# Altium schematic sheet PFM_GPRS.SchDoc(PFM_GPRS)
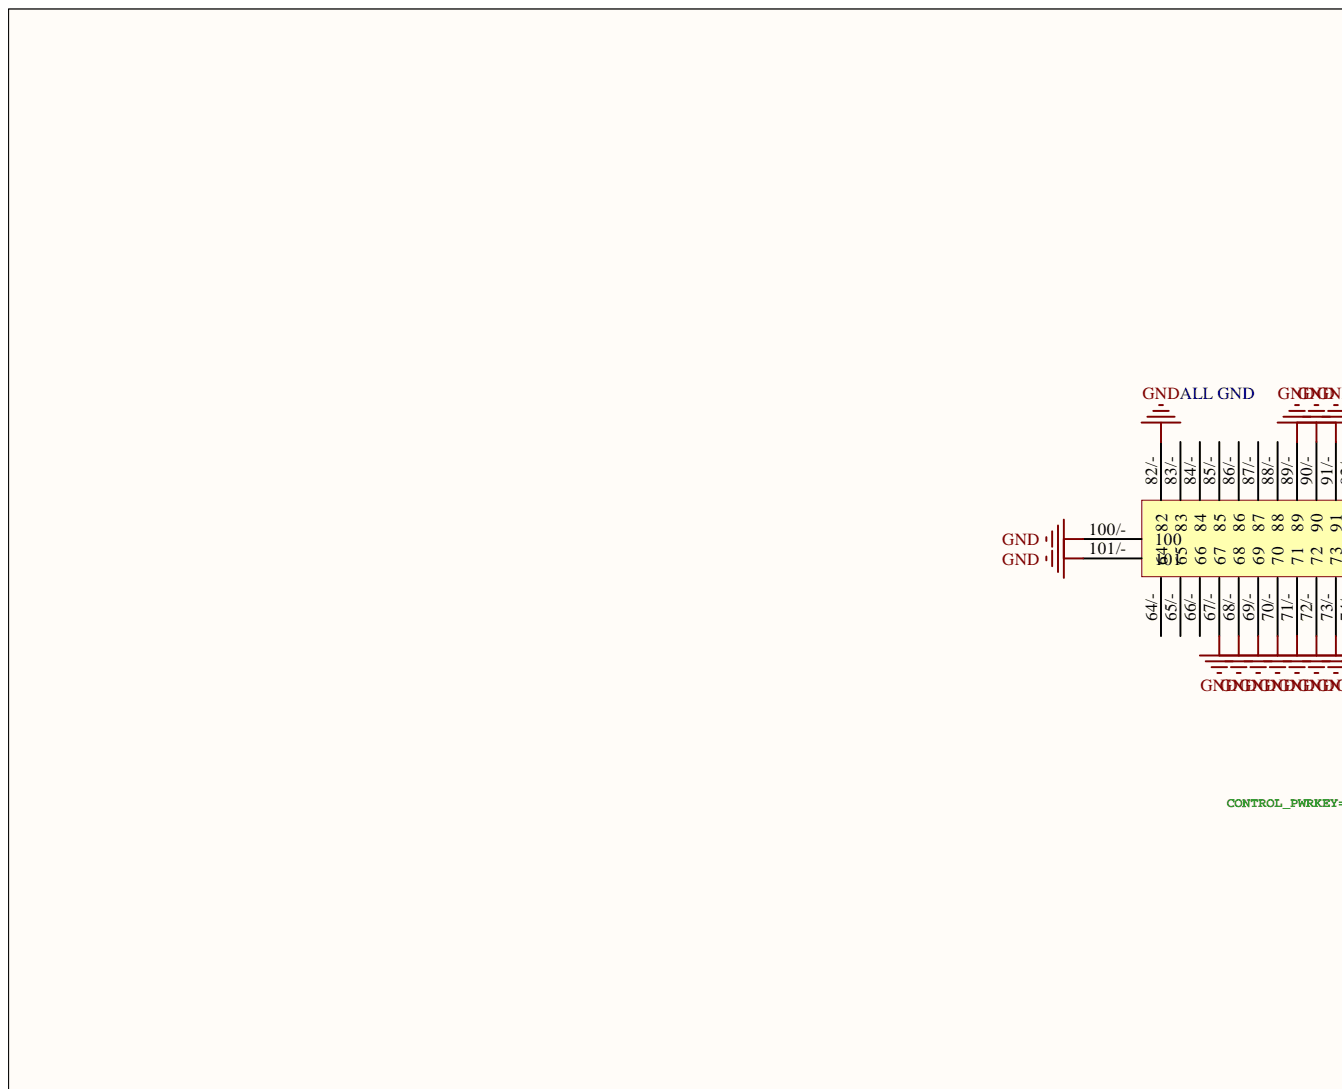
[diagram p0: PFM_GPRS.SchDoc, top left]
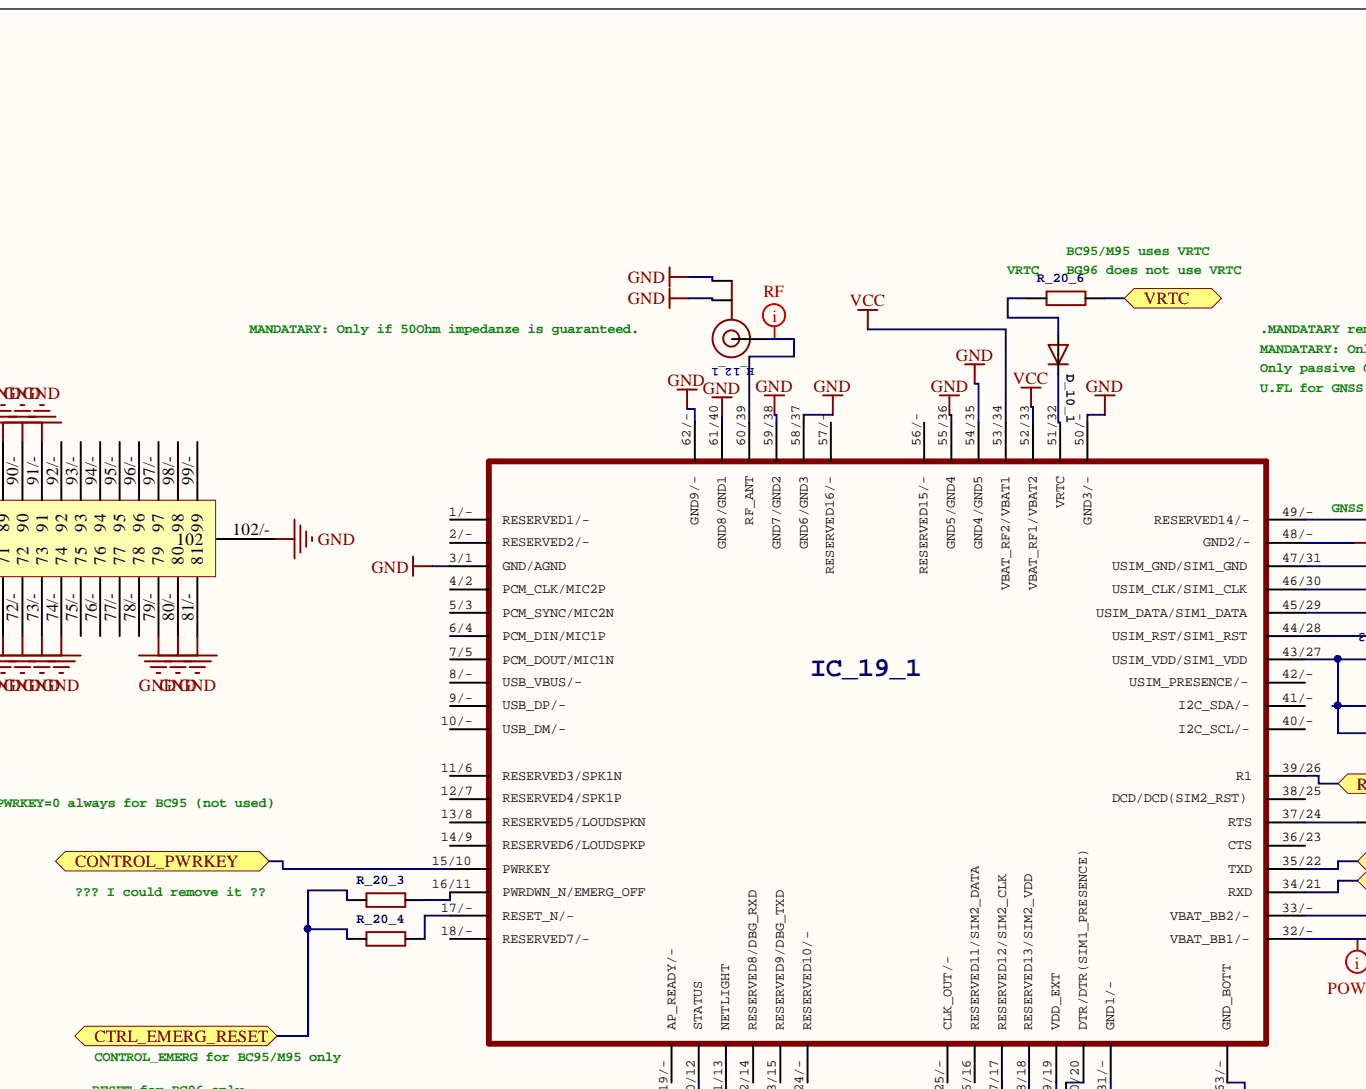
[diagram p1: PFM_GPRS.SchDoc, top centre]
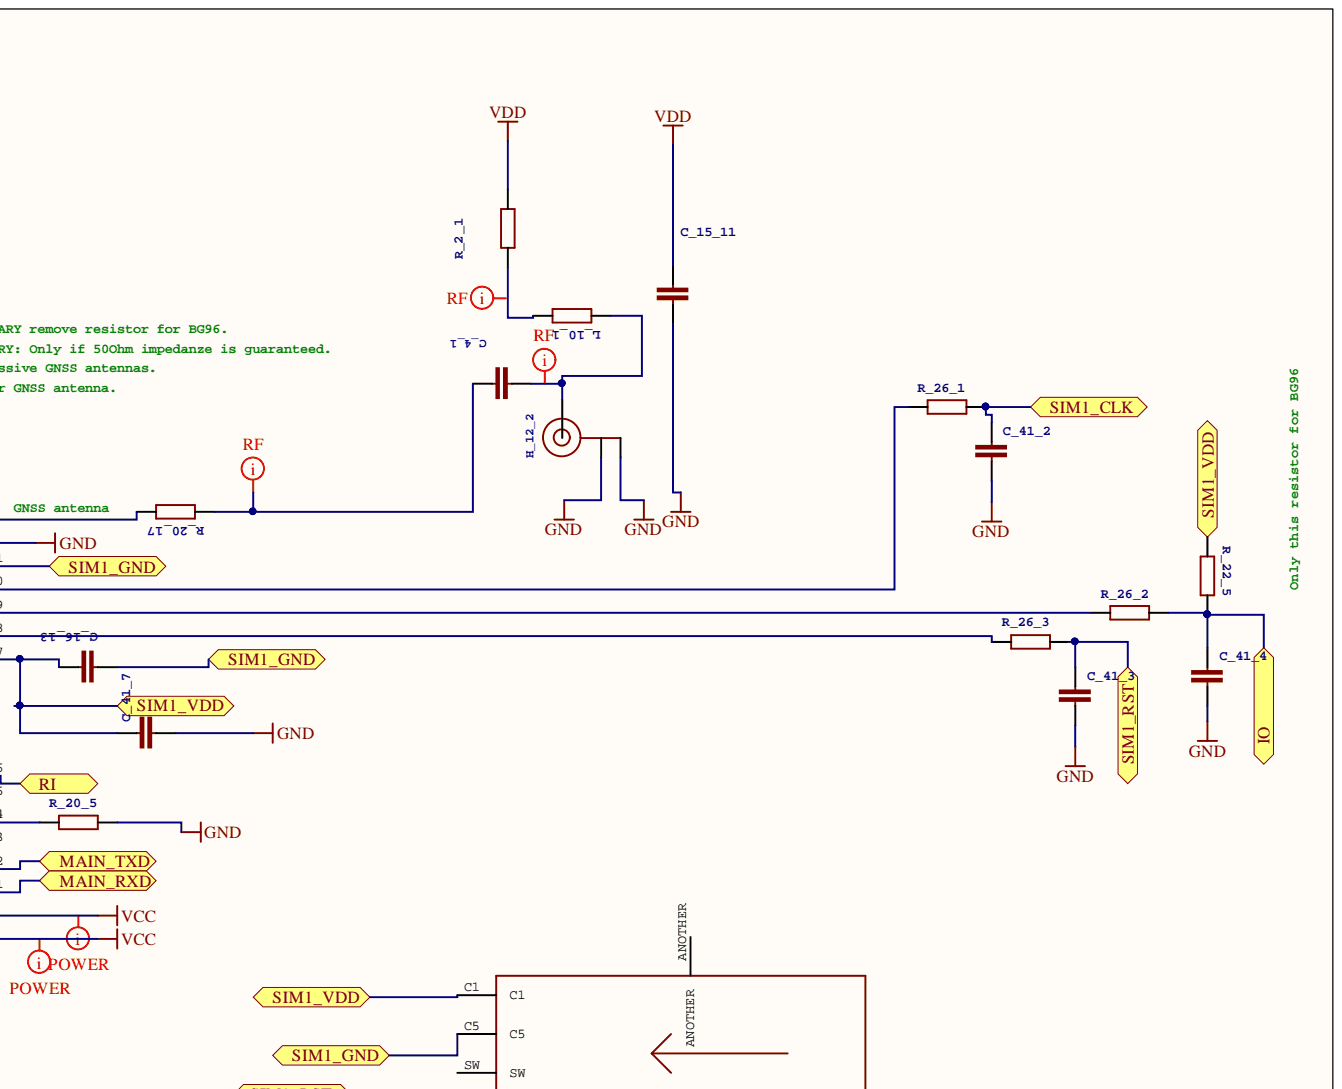
[diagram p2: PFM_GPRS.SchDoc, top right]
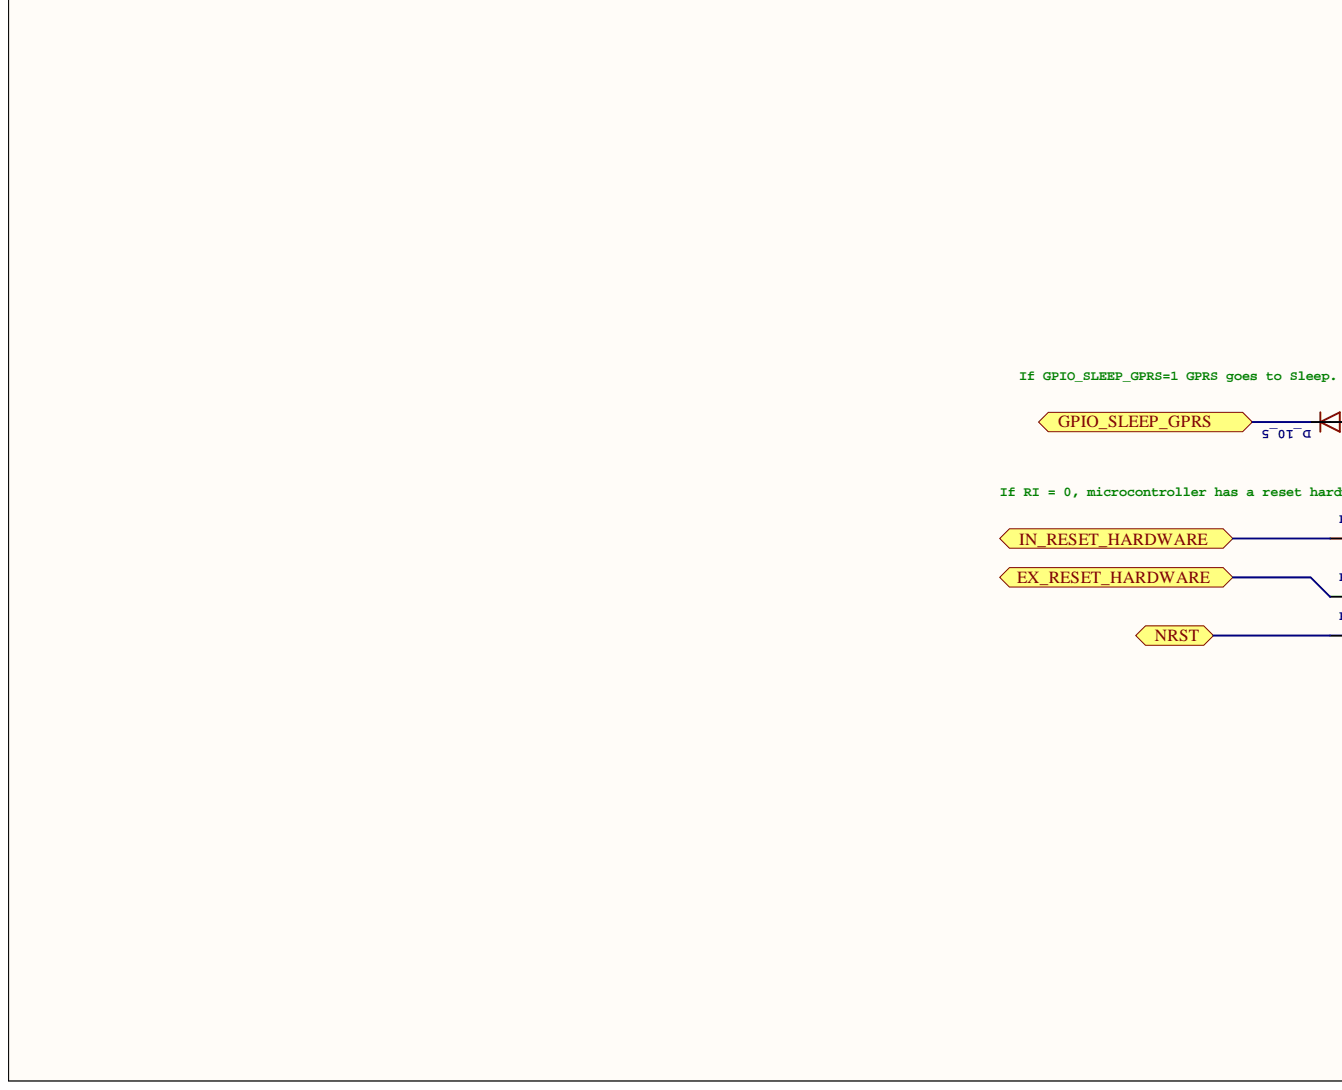
[diagram p3: PFM_GPRS.SchDoc, bottom left]
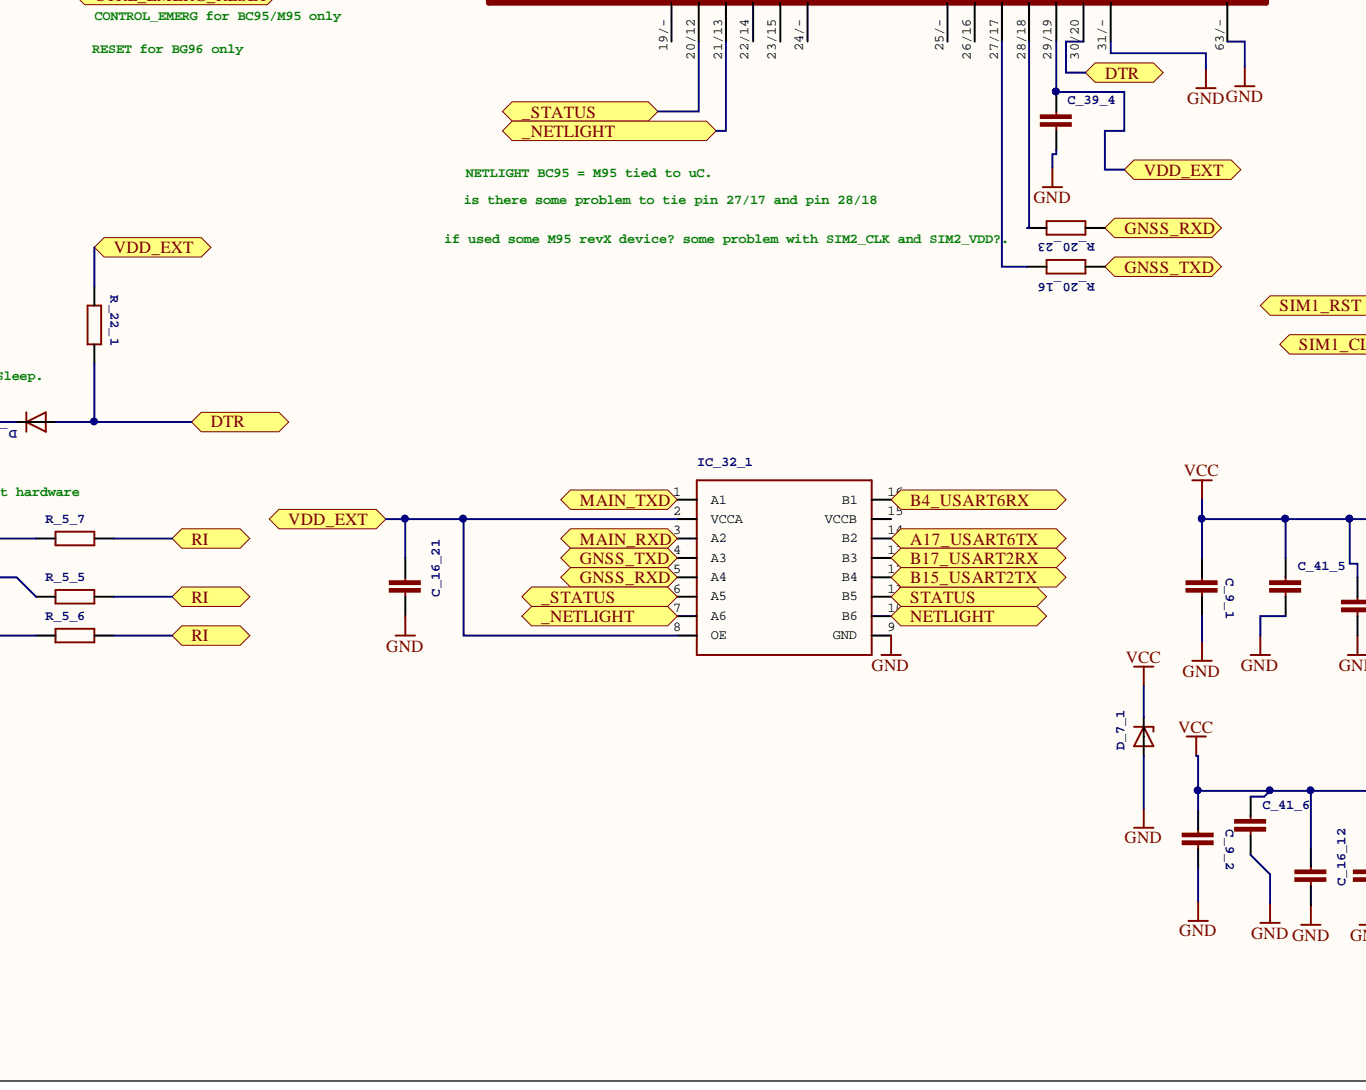
[diagram p4: PFM_GPRS.SchDoc, bottom centre]
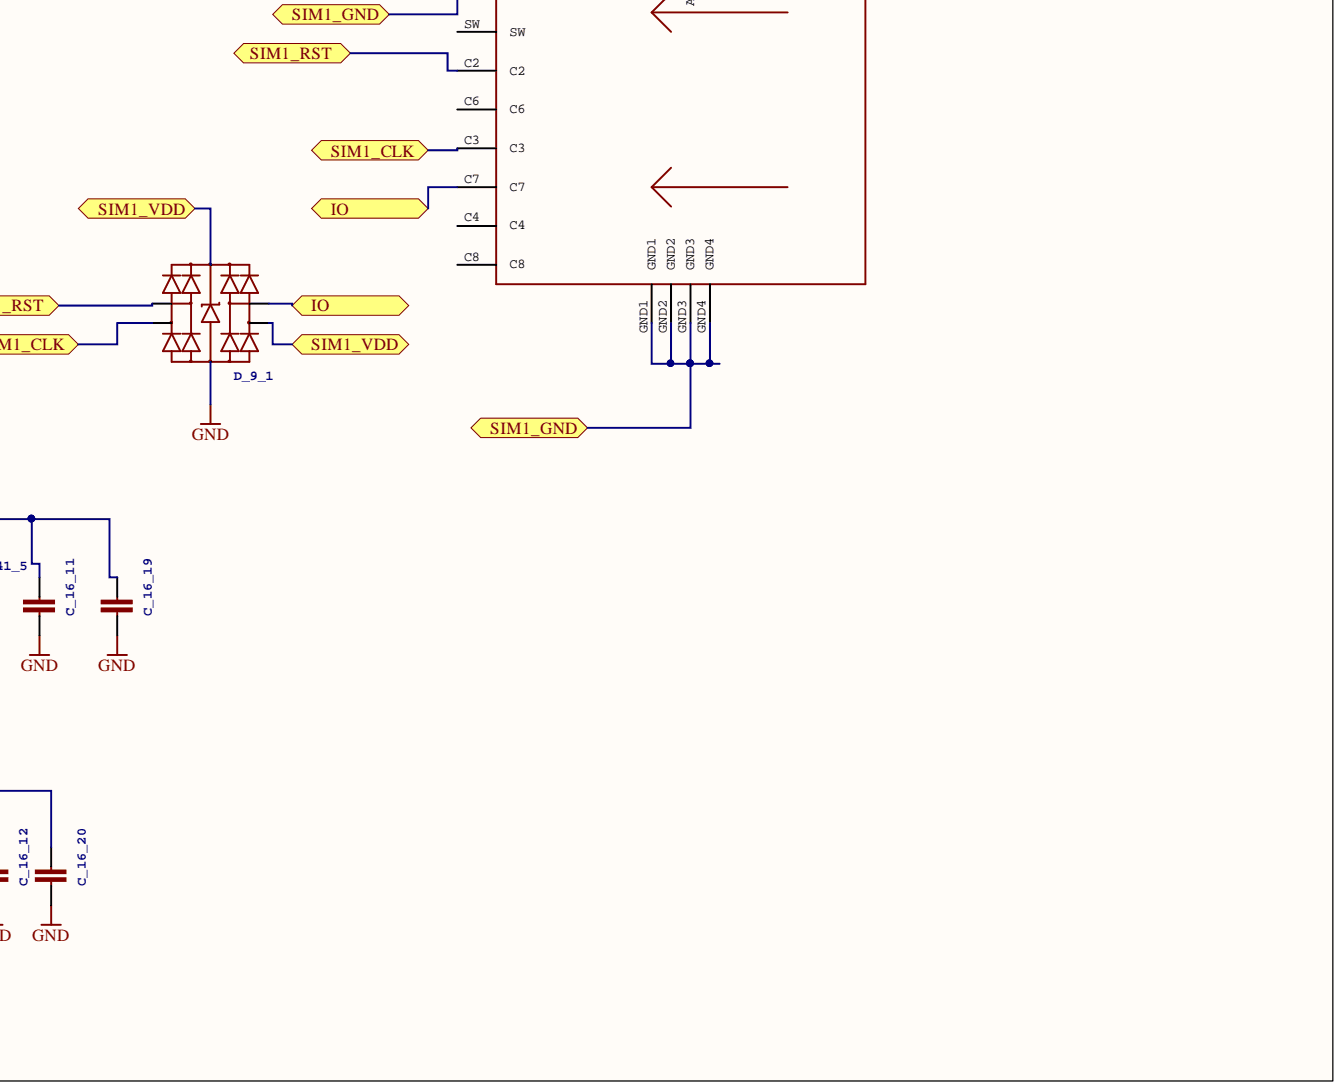
[diagram p5: PFM_GPRS.SchDoc, bottom right]

## Parts
C_4_1  100pF;C0402C101J5GACTU;KEMET;  [C0402]  (value not drawn)
C_9_1  100uF;TMCMB1A107MTRF;Vishay;  [C3216]  (value not drawn)
C_9_2  100uF;TMCMB1A107MTRF;Vishay;  [C3216]  (value not drawn)
C_15_11  100nF;GRM155R71A104KA01D;Murata;  [C0402]  (value not drawn)
C_16_11  100nF;CL10B104KB8NNNC;Samsung;  [C0603]  (value not drawn)
C_16_12  100nF;CL10B104KB8NNNC;Samsung;  [C0603]  (value not drawn)
C_16_13  100nF;CL10B104KB8NNNC;Samsung;  [C0603]  (value not drawn)
C_16_19  100nF;CL10B104KB8NNNC;Samsung;  [C0603]  (value not drawn)
C_16_20  100nF;CL10B104KB8NNNC;Samsung;  [C0603]  (value not drawn)
C_16_21  100nF;CL10B104KB8NNNC;Samsung;  [C0603]  (value not drawn)
C_39_4  4.7uF;CL10A475KO8NNNC;Samsung  [C0603]  (value not drawn)
C_41_2  33pF;C0603C330J5GACTU;Kemet  [C0603K]  (value not drawn)
C_41_3  33pF;C0603C330J5GACTU;Kemet  [C0603K]  (value not drawn)
C_41_4  33pF;C0603C330J5GACTU;Kemet  [C0603K]  (value not drawn)
C_41_5  33pF;C0603C330J5GACTU;Kemet  [C0603K]  (value not drawn)
C_41_6  33pF;C0603C330J5GACTU;Kemet  [C0603K]  (value not drawn)
C_41_7  33pF;C0603C330J5GACTU;Kemet  [C0603K]  (value not drawn)
D_7_1  ;TZM5231B-GS08;Vishay  [SOD80C]  (value not drawn)
D_9_1  TPD4E001DBV;Texas Instruments;  [SOT23-6]  (value not drawn)
D_10_1  SCHOTTKY;PMEG3010BER,115;NEXPERIA  [SOD123]  (value not drawn)
D_10_5  SCHOTTKY;PMEG3010BER,115;NEXPERIA  [SOD123]  (value not drawn)
H_12_1  ;U.FL-R-SMT-1(10);HIROSE  [U.FL]  (value not drawn)
H_12_2  ;U.FL-R-SMT-1(10);HIROSE  [U.FL]  (value not drawn)
H_21_1  MICROSIM;c-2199337;TE-Connectivity  [MICROSIM_BRD]  (value not drawn)
IC_19_1  M95;Quectel;  [UG95/M95]  (value not drawn)
IC_32_1  TXB0106;TEXAS INSTRUMENTS;  [HTSSOP16PW]  (value not drawn)
L_10_1  47nH;MCFT000034;MULTICOMP;  [R0402]  (value not drawn)
R_2_1  10;WR04X10R0FTL;WALSIN;  [R0402]  (value not drawn)
R_5_5  1k;ERJ-3GEYJ102V;Panasonic  [R0603]  (value not drawn)
R_5_6  1k;ERJ-3GEYJ102V;Panasonic  [R0603]  (value not drawn)
R_5_7  1k;ERJ-3GEYJ102V;Panasonic  [R0603]  (value not drawn)
R_20_3  0;ERJ3GEY0R00V;Panasonic;  [R0603]  (value not drawn)
R_20_4  0;ERJ3GEY0R00V;Panasonic;  [R0603]  (value not drawn)
R_20_5  0;ERJ3GEY0R00V;Panasonic;  [R0603]  (value not drawn)
R_20_6  0;ERJ3GEY0R00V;Panasonic;  [R0603]  (value not drawn)
R_20_16  0;ERJ3GEY0R00V;Panasonic;  [R0603]  (value not drawn)
R_20_17  0;ERJ3GEY0R00V;Panasonic;  [R0603]  (value not drawn)
R_20_23  0;ERJ3GEY0R00V;Panasonic;  [R0603]  (value not drawn)
R_22_1  10k;MCR03ERTJ103;ROHM SEMICONDUCTOR  [R0603]  (value not drawn)
R_22_5  10k;MCR03ERTJ103;ROHM SEMICONDUCTOR  [R0603]  (value not drawn)
R_26_1  22;CRCW060322R0FKEA;Vishay  [R0603]  (value not drawn)
R_26_2  22;CRCW060322R0FKEA;Vishay  [R0603]  (value not drawn)
R_26_3  22;CRCW060322R0FKEA;Vishay  [R0603]  (value not drawn)

## Ports
_NETLIGHT, _STATUS, A17_USART6TX, B4_USART6RX, B15_USART2TX, B17_USART2RX, CONTROL_PWRKEY, CTRL_EMERG_RESET, DTR, EX_RESET_HARDWARE, GNSS_RXD, GNSS_TXD, GPIO_SLEEP_GPRS, IN_RESET_HARDWARE, IO, MAIN_RXD, MAIN_TXD, NETLIGHT, NRST, RI, SIM1_CLK, SIM1_GND, SIM1_RST, SIM1_VDD, STATUS, VDD_EXT, VRTC

## Nets
GND: C_9_1.2 C_9_2.2 C_15_11.2 C_16_11.1 C_16_12.1 C_16_19.1 C_16_20.1 C_16_21.1 C_39_4.2 C_41_2.2 C_41_3.2 C_41_4.2 C_41_5.2 C_41_6.2 C_41_7.2 D_7_1.A D_9_1.2 H_12_1.1 H_12_1.2 H_12_2.1 H_12_2.2 IC_19_1.3/1(GND/AGND) IC_19_1.31/-(GND1/-) IC_19_1.48/-(GND2/-) IC_19_1.50/-(GND3/-) IC_19_1.54/35(GND4/GND5) IC_19_1.55/36(GND5/GND4) IC_19_1.58/37(GND6/GND3) IC_19_1.59/38(GND7/GND2) IC_19_1.61/40(GND8/GND1) IC_19_1.62/-(GND9/-) IC_19_1.63/-(GND_BOTT) IC_19_1.67/- IC_19_1.68/- IC_19_1.69/- IC_19_1.70/- IC_19_1.71/- IC_19_1.72/- IC_19_1.73/- IC_19_1.74/- IC_19_1.79/- IC_19_1.80/- IC_19_1.81/- IC_19_1.82/- IC_19_1.89/- IC_19_1.90/- IC_19_1.91/- IC_19_1.100/- IC_19_1.101/- IC_19_1.102/- IC_32_1.9(GND) R_20_5.2
VCC: C_9_1.1 C_9_2.1 C_16_11.2 C_16_12.2 C_16_19.2 C_16_20.2 C_41_5.1 C_41_6.1 D_7_1.C IC_19_1.32/-(VBAT_BB1/-) IC_19_1.33/-(VBAT_BB2/-) IC_19_1.52/33(VBAT_RF1/VBAT2) IC_19_1.53/34(VBAT_RF2/VBAT1)
VDD: C_15_11.1 R_2_1.2
_NETLIGHT: IC_19_1.21/13(NETLIGHT) IC_32_1.7(A6) ; port _NETLIGHT
_STATUS: IC_19_1.20/12(STATUS) IC_32_1.6(A5) ; port _STATUS
A17_USART6TX: IC_32_1.14(B2) ; port A17_USART6TX
B4_USART6RX: IC_32_1.16(B1) ; port B4_USART6RX
B15_USART2TX: IC_32_1.12(B4) ; port B15_USART2TX
B17_USART2RX: IC_32_1.13(B3) ; port B17_USART2RX
CONTROL_PWRKEY: IC_19_1.15/10(PWRKEY) ; port CONTROL_PWRKEY
CTRL_EMERG_RESET: R_20_3.1 R_20_4.1 ; port CTRL_EMERG_RESET
DTR: D_10_5.A IC_19_1.30/20(DTR/DTR(SIM1_PRESENCE)) R_22_1.2 ; port DTR
EX_RESET_HARDWARE: R_5_5.1 ; port EX_RESET_HARDWARE
GNSS_RXD: IC_32_1.5(A4) R_20_23.1 ; port GNSS_RXD
GNSS_TXD: IC_32_1.4(A3) R_20_16.1 ; port GNSS_TXD
GPIO_SLEEP_GPRS: D_10_5.C ; port GPIO_SLEEP_GPRS
IN_RESET_HARDWARE: R_5_7.1 ; port IN_RESET_HARDWARE
IO: C_41_4.1 D_9_1.4 H_21_1.C7 R_22_5.2 R_26_2.2 ; port IO
MAIN_RXD: IC_19_1.34/21(RXD) IC_32_1.3(A2) ; port MAIN_RXD
MAIN_TXD: IC_19_1.35/22(TXD) IC_32_1.1(A1) ; port MAIN_TXD
NETLIGHT: IC_32_1.10(B6) ; port NETLIGHT
NRST: R_5_6.1 ; port NRST
RI: IC_19_1.39/26(R1) R_5_5.2 R_5_6.2 R_5_7.2 ; port RI
SIM1_CLK: C_41_2.1 D_9_1.1 H_21_1.C3 R_26_1.2 ; port SIM1_CLK
SIM1_GND: C_16_13.1 H_21_1.C5 H_21_1.GND1 H_21_1.GND2 H_21_1.GND3 H_21_1.GND4 IC_19_1.47/31(USIM_GND/SIM1_GND) ; port SIM1_GND
SIM1_RST: C_41_3.1 D_9_1.3 H_21_1.C2 R_26_3.2 ; port SIM1_RST
SIM1_VDD: C_16_13.2 C_41_7.1 D_9_1.5 D_9_1.6 H_21_1.C1 IC_19_1.43/27(USIM_VDD/SIM1_VDD) R_22_5.1 ; port SIM1_VDD
STATUS: IC_32_1.11(B5) ; port STATUS
VDD_EXT: C_16_21.2 C_39_4.1 IC_19_1.29/19(VDD_EXT) IC_32_1.2(VCCA) IC_32_1.8(OE) R_22_1.1 ; port VDD_EXT
VRTC: R_20_6.2 ; port VRTC
NetC_4_1_1: C_4_1.1 R_20_17.1
NetC_4_1_2: C_4_1.2 H_12_2.3 L_10_1.1
NetD_10_1_A: D_10_1.A R_20_6.1
NetD_10_1_C: D_10_1.C IC_19_1.51/32(VRTC)
NetH_12_1_3: H_12_1.3 IC_19_1.60/39(RF_ANT)
NetIC_19_1_16/11: IC_19_1.16/11(PWRDWN_N/EMERG_OFF) R_20_3.2
NetIC_19_1_17/-: IC_19_1.17/-(RESET_N/-) R_20_4.2
NetIC_19_1_27/17: IC_19_1.27/17(RESERVED12/SIM2_CLK) R_20_16.2
NetIC_19_1_28/18: IC_19_1.28/18(RESERVED13/SIM2_VDD) R_20_23.2
NetIC_19_1_37/24: IC_19_1.37/24(RTS) R_20_5.1
NetIC_19_1_44/28: IC_19_1.44/28(USIM_RST/SIM1_RST) R_26_3.1
NetIC_19_1_45/29: IC_19_1.45/29(USIM_DATA/SIM1_DATA) R_26_2.1
NetIC_19_1_46/30: IC_19_1.46/30(USIM_CLK/SIM1_CLK) R_26_1.1
NetIC_19_1_49/-: IC_19_1.49/-(RESERVED14/-) R_20_17.2
NetL_10_1_2: L_10_1.2 R_2_1.1
N00017:

Not connected: H_21_1 ANOTHER C4 C6 C8 SW; IC_19_1 1/-(RESERVED1/-) 2/-(RESERVED2/-) 4/2(PCM_CLK/MIC2P) 5/3(PCM_SYNC/MIC2N) 6/4(PCM_DIN/MIC1P) 7/5(PCM_DOUT/MIC1N) 8/-(USB_VBUS/-) 9/-(USB_DP/-) 10/-(USB_DM/-) 11/6(RESERVED3/SPK1N) 12/7(RESERVED4/SPK1P) 13/8(RESERVED5/LOUDSPKN) 14/9(RESERVED6/LOUDSPKP) 18/-(RESERVED7/-) 19/-(AP_READY/-) 22/14(RESERVED8/DBG_RXD) 23/15(RESERVED9/DBG_TXD) 24/-(RESERVED10/-) 25/-(CLK_OUT/-) 26/16(RESERVED11/SIM2_DATA) 36/23(CTS) 38/25(DCD/DCD(SIM2_RST)) 40/-(I2C_SCL/-) 41/-(I2C_SDA/-) 42/-(USIM_PRESENCE/-) 56/-(RESERVED15/-) 57/-(RESERVED16/-) 64/- 65/- 66/- 75/- 76/- 77/- 78/- 83/- 84/- 85/- 86/- 87/- 88/- 92/- 93/- 94/- 95/- 96/- 97/- 98/- 99/-; IC_32_1 15(VCCB)

## Library data of the parts
C_4_1 C_15_11 = C-EUC0402: CAPACITOR, European symbol; Library Name: rcl.IntLib; Component Kind: Standard
C_9_1 C_9_2 = C-EUC3216: CAPACITOR, European symbol; Library Name: rcl.IntLib; Component Kind: Standard
C_16_11-C_16_13 C_16_19-C_16_21 C_39_4 = C-EUC0603: Library Name: PBv4p0.SCHLIB; Component Kind: Standard
C_41_2-C_41_7 = C-EUC0603K: Component Kind: Standard; Library Name: Easynet_Commboard_v1p1.IntLib
D_7_1 = ZENER-DIODESOD80C: Z-Diode; Library Name: diode.IntLib; Component Kind: Standard
D_9_1 = TPD4E001DBV: 4-CHANNEL ESD PROTECTION ARRAY WITH 1.5-pF IO CAPACITANCE  4-Channel ESD Clamp Array to Enhance System-Level ESD Protection  Exceeds IEC61000-4-2 (Level 4) ESD Protection Requirements  ï¿½8-kV IEC 61000-4-2 Contact Discharge  ï¿½15-kV IEC 61000-4-2 Air-Gap Discharge  ï¿½15-kV Human-Body Model (HBM)  5.5-A Peak Pulse Current (8/20-us Pulse)  Low 1.5-pF Input Capacitance  Low 1-nA (Max) Leakage Current  0.9-V to 5.5-V Supply-Voltage Range  Space-Saving DRL, DBV, DCK, and DRS Package Options  Alternate 2-, 3-, 6-Channel Options Available: TPD2E001, TPD3E001, and TPD6E001       DESCRIPTION   The TPD4E001 is a low-capacitance ï¿½15-kV ESD-protection diode array designed to protect sensitive electronics attached to communication lines. Each channel consists of a pair of diodes that steer ESD current pulses to VCC or GND. The TPD4E001 protects against ESD pulses up to ï¿½15-kV Human-Body Model (HBM), ï¿½8-kV Contact Discharge, and ï¿½15-kV Air-Gap Discharge, as specified in IEC 61000-4-2. This device has a 1.5-pF IO capacitance per channel, making it ideal for use in high-speed data IO interfaces. The ultra low leakage current (1 nA max) is suitable for precision analog measurements in applications like glucose meters, heart rate monitors, etc. The TPD4E001 is available in DRL, DBV (SOT-23), DCK (SC-70), DRS (QFN), and DPK (PUSON) packages and is specified for ï¿½40ï¿½C to 85ï¿½C operation.; MANUF: TI; PARTNUM: TPD4E001DBV; Library Name: TVS.IntLib; Component Kind: Standard
D_10_1 D_10_5 = DIODE-SOD123: DIODE; Library Name: diode.IntLib; Component Kind: Standard
H_12_1 H_12_2 = ANTENNA_U.FL: U.FL Antenna Connector; Library Name: adafruit.IntLib; Component Kind: Standard
H_21_1 = MICROSIM_ENLACE: Component Kind: Standard; Library Name: MICROSIM_TECONNECTIVITY.IntLib
IC_19_1 = UG95/M95: Component Kind: Standard; Library Name: Easynet_Commboard_v1p1.SCHLIB
IC_32_1 = TXB0106: 6-Bit Bidirectional Voltage-Level Translator; MPN: ISO7242MDW; OC_NEWAR=K: 73M3163; OC_FARNELL: 1755127; Component Kind: Standard; Library Name: M2MminiPCI-E36_v2p4.SCHLIB
L_10_1 R_2_1 = R-EU_R0402: RESISTOR, European symbol; Library Name: rcl.IntLib; Component Kind: Standard
R_5_5-R_5_7 R_20_3-R_20_6 R_20_16 R_20_17 R_20_23 R_22_1 R_22_5 R_26_1-R_26_3 = R-EU_R0603: RESISTOR, European symbol; Library Name: PBv4p0.SCHLIB; Component Kind: Standard
